# Altium schematic sheet VLF_PreAmp_v3.SchDoc(VLF_PreAmp_v3)
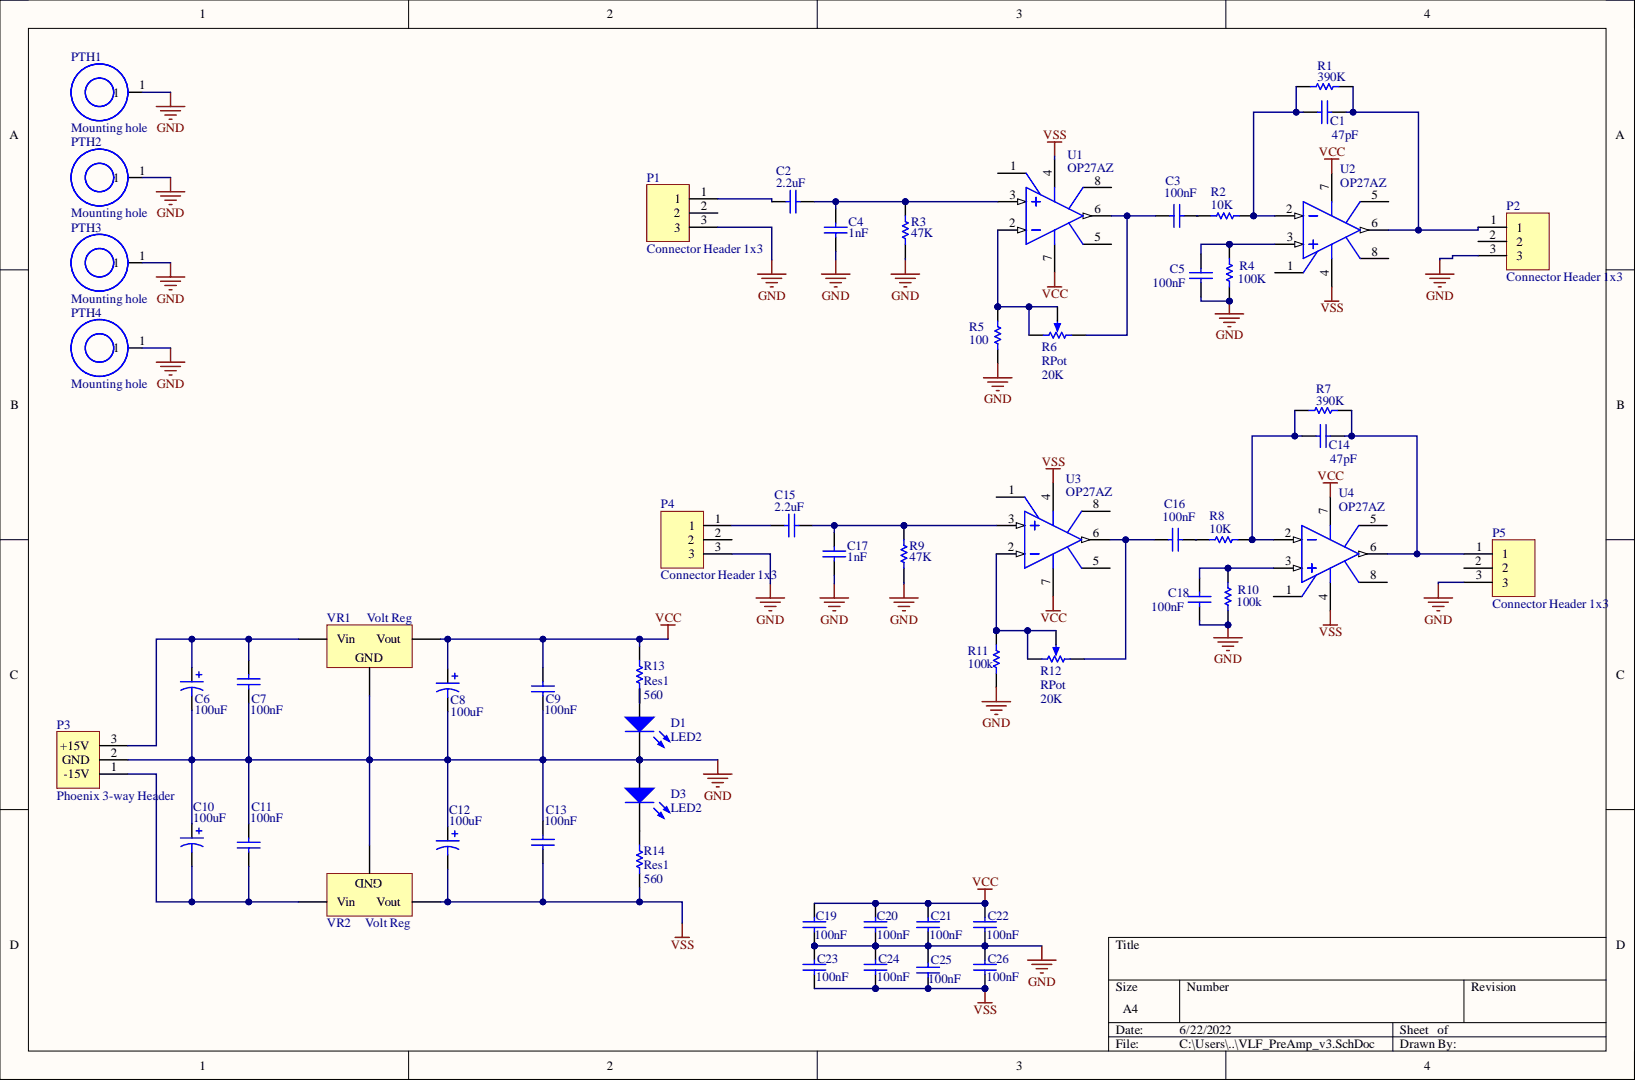
[diagram p0: VLF_PreAmp_v3.SchDoc]

## Parts
C1  value 47pF  [1206(Cap)]  (hidden comment: Cap)
C2  value 2.2uF  [1206(Cap)]  (hidden comment: Cap)
C3  value 100nF  [1206(Cap)]  (hidden comment: Cap)
C4  value 1nF  [1206(Cap)]  (hidden comment: Cap)
C5  value 100nF  [1206(Cap)]  (hidden comment: Cap)
C6  value 100uF  [Case_D]  (hidden comment: Cap Pol1)
C7  value 100nF  [1206(Cap)]  (hidden comment: Cap)
C8  value 100uF  [Case_D]  (hidden comment: Cap Pol1)
C9  value 100nF  [1206(Cap)]  (hidden comment: Cap)
C10  value 100uF  [Case_D]  (hidden comment: Cap Pol1)
C11  value 100nF  [1206(Cap)]  (hidden comment: Cap)
C12  value 100uF  [Case_D]  (hidden comment: Cap Pol1)
C13  value 100nF  [1206(Cap)]  (hidden comment: Cap)
C14  value 47pF  [1206(Cap)]  (hidden comment: Cap)
C15  value 2.2uF  [1206(Cap)]  (hidden comment: Cap)
C16  value 100nF  [1206(Cap)]  (hidden comment: Cap)
C17  value 1nF  [1206(Cap)]  (hidden comment: Cap)
C18  value 100nF  [1206(Cap)]  (hidden comment: Cap)
C19  value 100nF  [1206(Cap)]  (hidden comment: Cap)
C20  value 100nF  [1206(Cap)]  (hidden comment: Cap)
C21  value 100nF  [1206(Cap)]  (hidden comment: Cap)
C22  value 100nF  [1206(Cap)]  (hidden comment: Cap)
C23  value 100nF  [1206(Cap)]  (hidden comment: Cap)
C24  value 100nF  [1206(Cap)]  (hidden comment: Cap)
C25  value 100nF  [1206(Cap)]  (hidden comment: Cap)
C26  value 100nF  [1206(Cap)]  (hidden comment: Cap)
D1  LED2  [3.2X1.6X1.1]
D3  LED2  [3.2X1.6X1.1]
P1  Connector Header 1x3  [Header1X3]
P2  Connector Header 1x3  [Header1X3]
P3  Phoenix 3-way Header  [3x2 Phoenix]
P4  Connector Header 1x3  [Header1X3]
P5  Connector Header 1x3  [Header1X3]
PTH1  Mounting hole  [Mounting Hole]
PTH2  Mounting hole  [Mounting Hole]
PTH3  Mounting hole  [Mounting Hole]
PTH4  Mounting hole  [Mounting Hole]
R1  value 390K  [Resistor 1206 - Outline]  (hidden comment: Res1)
R2  value 10K  [Resistor 1206 - Outline]  (hidden comment: Res1)
R3  value 47K  [Resistor 1206 - Outline]  (hidden comment: Res1)
R4  value 100K  [Resistor 1206 - Outline]  (hidden comment: Res1)
R5  value 100  [Resistor 1206 - Outline]  (hidden comment: Res1)
R6  RPot  value 20K  [VR5]
R7  value 390K  [Resistor 1206 - Outline]  (hidden comment: Res1)
R8  value 10K  [Resistor 1206 - Outline]  (hidden comment: Res1)
R9  value 47K  [Resistor 1206 - Outline]  (hidden comment: Res1)
R10  value 100k  [Resistor 1206 - Outline]  (hidden comment: Res1)
R11  value 100k  [Resistor 1206 - Outline]  (hidden comment: Res1)
R12  RPot  value 20K  [VR5]
R13  Res1  value 560  [Resistor 1206 - Outline]
R14  Res1  value 560  [Resistor 1206 - Outline]
U1  OP27AZ  [Q-8]
U2  OP27AZ  [Q-8]
U3  OP27AZ  [Q-8]
U4  OP27AZ  [Q-8]
VR1  Volt Reg  [D2PAK_N]
VR2  Volt Reg  [D2PAK_N]

## Nets
GND: C4.1 C5.2 C6.2 C7.1 C8.2 C9.1 C10.1 C11.2 C12.1 C13.2 C17.1 C18.2 C19.1 C20.1 C21.1 C22.1 C23.2 C24.2 C25.2 C26.2 D1.2 D3.1 P1.3 P2.3 P3.2 P4.3 P5.3 PTH1.1 PTH2.1 PTH3.1 PTH4.1 R3.2 R4.2 R5.2 R9.2 R10.2 R11.2 VR1.2 VR2.1
VCC: C8.1 C9.2 C19.2 C20.2 C21.2 C22.2 R13.2 U1.7 U2.7 U3.7 U4.7 VR1.3
VSS: C12.2 C13.1 C23.1 C24.1 C25.1 C26.1 R14.1 U1.4 U2.4 U3.4 U4.4 VR2.3
NetC1_1: C1.1 R1.1 R2.2 U2.2
NetC1_2: C1.2 P2.1 R1.2 U2.6
NetC2_1: C2.1 P1.1
NetC2_2: C2.2 C4.2 R3.1 U1.3
NetC3_1: C3.1 R6.1 U1.6
NetC3_2: C3.2 R2.1
NetC5_1: C5.1 R4.1 U2.3
NetC6_1: C6.1 C7.2 P3.3 VR1.1
NetC10_2: C10.2 C11.1 P3.1 VR2.2
NetC14_1: C14.1 R7.1 R8.2 U4.2
NetC14_2: C14.2 P5.1 R7.2 U4.6
NetC15_1: C15.1 P4.1
NetC15_2: C15.2 C17.2 R9.1 U3.3
NetC16_1: C16.1 R12.1 U3.6
NetC16_2: C16.2 R8.1
NetC18_1: C18.1 R10.1 U4.3
NetD1_1: D1.1 R13.1
NetD3_2: D3.2 R14.2
NetR5_1: R5.1 R6.2 R6.3 U1.2
NetR11_1: R11.1 R12.2 R12.3 U3.2

Not connected: P1 2; P2 2; P4 2; P5 2; U1 1 5 8; U2 1 5 8; U3 1 5 8; U4 1 5 8

## Library data of the parts
C1-C5 C7 C9 C11 C13-C26 = Cap: Capacitor; Component Kind: Standard; LatestRevisionDate: 17-Jul-2002; LatestRevisionNote: Re-released for DXP Platform.; Library Name: Miscellaneous Devices.IntLib; PackageDescription: Radial Cap, Thru-Hole; 2 Leads; 0.3 in Pin Spacing; PackageReference: RAD-0.3; Published: 8-Jun-2000; Signal Integrity: Cap; Simulation: CAP; Publisher: Altium Limited
C6 C8 C10 C12 = Cap Pol1: Polarized Capacitor (Radial); Component Kind: Standard; LatestRevisionDate: 17-Jul-2002; LatestRevisionNote: Re-released for DXP Platform.; Library Name: Miscellaneous Devices.IntLib; PackageDescription: Polarized Capacitor (Radial); 2 Leads; PackageReference: RB7.6-15; Published: 8-Jun-2000; Signal Integrity: Cap Pol; Simulation: CAP; Publisher: Altium Limited
D1 D3 = LED2: Typical RED, GREEN, YELLOW, AMBER GaAs LED; Component Kind: Standard; LatestRevisionDate: 17-Jul-2002; LatestRevisionNote: Re-released for DXP Platform.; Library Name: Miscellaneous Devices.IntLib; PackageDescription: SM LED; 2 Flat Leads; PackageReference: 3.2X1.6X1.1; PackageVersion: Feb-2002; Published: 8-Jun-2000; Simulation: LED2; Publisher: Altium Limited
P1 P2 P4 P5 = WM22764-ND: Conn Wire to Board HDR 3 POS 2.54mm Solder ST Thru-Hole Bulk; Manufacturer: Molex; Catagory: Connectors; Category: Connectors; Component Kind: Standard; ComponentLink1Description: http://www.mouser.com/ds/2/276/022232037_sd-589157.pdf?src-supplier=Mouser; ComponentLink1URL: http://www.mouser.com/ds/2/276/022232037_sd-589157.pdf?src-supplier=Mouser; ComponentLink2Description: http://components.arrow.com/part/dataview/4703599S8418778N4496?src-supplier=Arrow+Electronics; ComponentLink2URL: http://components.arrow.com/part/dataview/4703599S8418778N4496?src-supplier=Arrow+Electronics; Library Name: Schlib1.SchLib; Manufacturer Part Number: 22-23-2037; Pricing 1: 1=0,43000, 10=0,34800, 100=0,25530, 1000=0,20304, 5000=0,17404, 10000=0,16244 (USD); RoHS: Yes; Stock 1: 8640; Supplier 1: Digi-Key; Supplier Part Number 1: WM22764-ND
P3 = 1755749: Terminal Header; 12 A; 250 V; 5.08 mm; 3; Solder Mount; 1.4 mm; 4 kV; 250 V; Manufacturer: Phoenix Contact; Approvals: UL,CSA,VDE,cULus,CB,EAC; Connector Type: Plug; Current: 12A; Number of Contacts: 3; Number of Levels: 1; Pitch: 5.08mm; Voltage: 300V; Voltage Rating: 250V; Category: Terminal Blocks; Color: Grey,Green; Component Kind: Standard; ComponentLink1Description: https://datasheet.ciiva.com/16096/1755749-16096362.pdf?src-supplier=Onlinecomponents.com; ComponentLink1URL: https://datasheet.ciiva.com/16096/1755749-16096362.pdf?src-supplier=Onlinecomponents.com; ComponentLink2Description: https://datasheet.ciiva.com/15197/getdatasheetpartid-176345-15197315.pdf?src-supplier=Verical; ComponentLink2URL: https://datasheet.ciiva.com/15197/getdatasheetpartid-176345-15197315.pdf?src-supplier=Verical; ComponentLink3Description: https://datasheet.ciiva.com/795/1755749-795419.pdf?src-supplier=Digi-Key; ComponentLink3URL: https://datasheet.ciiva.com/795/1755749-795419.pdf?src-supplier=Digi-Key; ComponentLink4Description: https://datasheet.ciiva.com/29210/569612-da-01-en-grundgehaeuse-1755749-250-st-29210780.pdf?src-supplier=Conrad; ComponentLink4URL: https://datasheet.ciiva.com/29210/569612-da-01-en-grundgehaeuse-1755749-250-st-29210780.pdf?src-supplier=Conrad; ComponentLink5Description: https://datasheet.ciiva.com/33105/1755749-33105914.pdf?src-supplier=Today+Components; ComponentLink5URL: https://datasheet.ciiva.com/33105/1755749-33105914.pdf?src-supplier=Today+Components; Contact Material: Tin; Contact Plating: Tin; Current Rating: 12A; Depth: 8.6mm; Flammability Rating: UL94 V-0; Height: 15.9mm; Housing Color: Green; Housing Material: Polybutylene; Lead Free: Lead Free; Length: 17.24mm; Library Name: Schlib1.SchLib; Manufacturer Part Number: 1755749; Max Current Rating: 12A; Mount: Through Hole; Number of Poles: 3; Number of Positions: 3; Number of Positions Per Level: 3; Number of Rows: 1; Orientation: Straight; Package Quantity: 50; Packaging: Bulk; Pricing 1: 1=1,09090, 10=1,04700, 50=0,99460, 100=0,95960, 250=0,89070, 500=0,81690, 1000=0,75120, 2500=0,74370, 5000=0,66260 (USD); REACH SVHC: Unknown; RoHS: Yes; RoHS Compliant: Yes; Series: MSTBVA; Stock 1: 9628; Supplier 1: Arrow Electronics; Supplier Part Number 1: 1755749; Termination: Solder; Voltage Rating (DC): 250V; Weight: 5.467464oz; Width: 17.24mm
PTH1-PTH4 = Mounting hole: 3.2mm mounting hole; 6mm Diameter; Component Kind: Standard; Library Name: Schlib1.SchLib
R1-R5 R7-R11 R13 R14 = Res1: Resistor; Component Kind: Standard; LatestRevisionDate: 17-Jul-2002; LatestRevisionNote: Re-released for DXP Platform.; Library Name: custom.SchLib; PackageDescription: Axial Device, Thru-Hole; 2 Leads; 0.3 in Pin Spacing; PackageReference: AXIAL-0.3; Published: 8-Jun-2000; Signal Integrity: Res; Simulation: RESISTOR; Publisher: Altium Limited
R6 R12 = RPot: Potentiometer; Set Position: 0.5; Sim Note: Enter the Resistance value and the set point into parameter fields.; Component Kind: Standard; LatestRevisionDate: 17-Jul-2002; LatestRevisionNote: Re-released for DXP Platform.; Library Name: Miscellaneous Devices.IntLib; PackageDescription: Variable Resistor, Thru-Hole; 3 Leads; PackageReference: VR5; Published: 8-Jun-2000; Simulation: POT; Publisher: Altium Limited
U1-U4 = OP27AZ: Low-Noise, Precision Operational Amplifier; Note: Pin 5 is not connected.; Component Kind: Standard; ComponentLink1Description: Manufacturer Link; ComponentLink1URL: http://www.analog.com/en/prod/0,,759_786_OP27%2C00.html; ComponentLink2Description: Datasheet; ComponentLink2URL: http://www.analog.com/UploadedFiles/Data_Sheets/314538596OP27_c.pdf; DatasheetVersion: 1992; LatestRevisionDate: 04-Mar-2005; LatestRevisionNote: Stylized 3D Model Added.; Library Name: AD Operational Amplifier.IntLib; PackageDescription: DIP; 8 Leads; Row Spacing 7.62 mm; Pitch 2.54 mm; PackageReference: Q-8; PackageVersion: 1998; PCB3D: Q-8; Published: 8-Jun-2000; Simulation: OP27A; Publisher: Altium Limited
VR1 VR2 = Volt Reg: Voltage Regulator; Component Kind: Standard; LatestRevisionDate: 29-May-2009; LatestRevisionNote: IPC-7351 Footprint Added.; Library Name: Miscellaneous Devices.IntLib; PackageDescription: 3-Pin Transistor Outline Package 2.54 mm Pitch, 15.1 mm Lead Span; PackageReference: D2PAK; Published: 24-Mar-1999; Publisher: Altium Limited
